# Altium schematic sheet MCU.SchDoc(MCU)
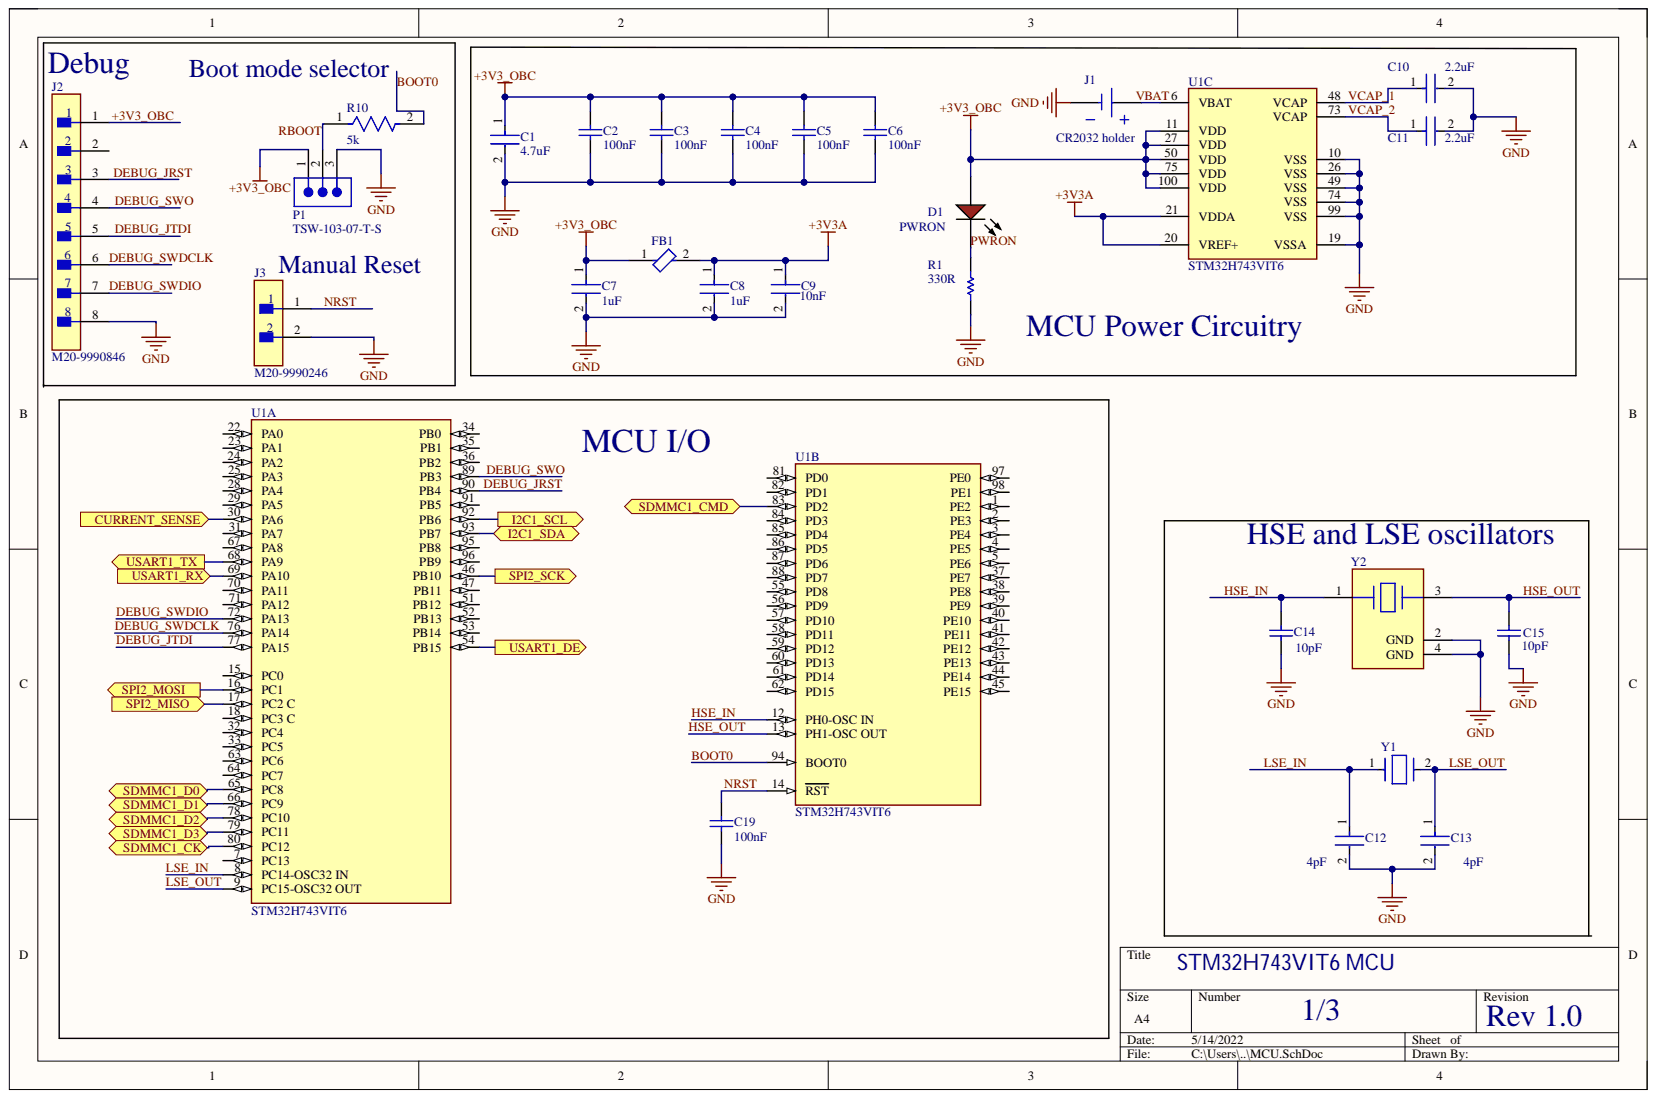
[diagram p0: MCU.SchDoc]

## Parts
C1  4.7uF  [FP-0805-L_2_0_0_1-W_1_25-IPC_B]
C2  100nF  [SAMS-C0603-2_M]
C3  100nF  [SAMS-C0603-2_M]
C4  100nF  [SAMS-C0603-2_M]
C5  100nF  [SAMS-C0603-2_M]
C6  100nF  [SAMS-C0603-2_M]
C7  1uF  [FP-CL10-IPC_C]
C8  1uF  [FP-CL10-IPC_C]
C9  10nF  [FP-CC0402-MFG]
C10  2.2uF  [FP-CC0805-0_85-MFG]
C11  2.2uF  [FP-CC0805-0_85-MFG]
C12  4pF  [FP-GRM033-0_03-IPC_B]
C13  4pF  [FP-GRM033-0_03-IPC_B]
C14  10pF  [CAPC1005X55X25ML05T05]
C15  10pF  [CAPC1005X55X25ML05T05]
C19  100nF  [SAMS-C0603-2_M]
D1  PWRON  [EVRL-0603-2_V]
FB1  MMZ1005D121CTD25  [FP-MMZ1005-IPC_C]  (value not drawn)
J1  CR2032 holder  [KEYSTONE-1025-7-2_V]
J2  M20-9990846  [FP-M20-9990846-MFG]
J3  M20-9990246  [FP-M20-9990246-MFG]
P1  TSW-103-07-T-S  [SMTC-TSW-103-07-X-S]
R1  330R  [RESC1608X55X25NL10T15]
R10  5k  [FP-MCR01-MFG]
U1  STM32H743VIT6  [FP-LQFP100-1L-MFG]  (parts A,B,C)
Y1  ABS06-107-32.768KHZ-T  [FP-ABS06-MFG]  (value not drawn)
Y2  Load Capacitance: 10pF  [FP-ABM3B-MFG]  (hidden comment: ABM3B-25.000MHZ-10-1-U-T)

## Ports
CURRENT_SENSE, I2C1_SCL, I2C1_SDA, SDMMC1_CK, SDMMC1_CMD, SDMMC1_D0, SDMMC1_D1, SDMMC1_D2, SDMMC1_D3, SPI2_MISO, SPI2_MOSI, SPI2_SCK, USART1_DE, USART1_RX, USART1_TX

## Nets
+3V3A: C8.1 C9.1 FB1.2 U1.20(VREF+) U1.21(VDDA)
GND: C1.2 C2.1 C3.1 C4.1 C5.1 C6.1 C7.2 C8.2 C9.2 C10.2 C11.2 C12.2 C13.2 C14.1 C15.1 C19.1 J1.2 J2.8 J3.2 P1.3 R1.1 U1.10(VSS) U1.19(VSSA) U1.26(VSS) U1.49(VSS) U1.74(VSS) U1.99(VSS) Y2.2(GND) Y2.4(GND)
+3V3_OBC: C1.1 C2.2 C3.2 C4.2 C5.2 C6.2 C7.1 D1.1 FB1.1 J2.1 P1.1 U1.11(VDD) U1.27(VDD) U1.50(VDD) U1.75(VDD) U1.100(VDD)
BOOT0: R10.2 U1.94(BOOT0)
CURRENT_SENSE: U1.30(PA6) ; port CURRENT_SENSE
DEBUG_JRST: J2.3 U1.90(PB4)
DEBUG_JTDI: J2.5 U1.77(PA15)
DEBUG_SWDCLK: J2.6 U1.76(PA14)
DEBUG_SWDIO: J2.7 U1.72(PA13)
DEBUG_SWO: J2.4 U1.89(PB3)
HSE_IN: C14.2 U1.12(PH0-OSC_IN) Y2.1
HSE_OUT: C15.2 U1.13(PH1-OSC_OUT) Y2.3
I2C1_SCL: U1.92(PB6) ; port I2C1_SCL
I2C1_SDA: U1.93(PB7) ; port I2C1_SDA
LSE_IN: C12.1 U1.8(PC14-OSC32_IN) Y1.1
LSE_OUT: C13.1 U1.9(PC15-OSC32_OUT) Y1.2
NRST: C19.2 J3.1 U1.14(RST)
PWRON: D1.2 R1.2
SDMMC1_CK: U1.80(PC12) ; port SDMMC1_CK
SDMMC1_CMD: U1.83(PD2) ; port SDMMC1_CMD
SDMMC1_D0: U1.65(PC8) ; port SDMMC1_D0
SDMMC1_D1: U1.66(PC9) ; port SDMMC1_D1
SDMMC1_D2: U1.78(PC10) ; port SDMMC1_D2
SDMMC1_D3: U1.79(PC11) ; port SDMMC1_D3
SPI2_MISO: U1.17(PC2_C) ; port SPI2_MISO
SPI2_MOSI: U1.16(PC1) ; port SPI2_MOSI
SPI2_SCK: U1.46(PB10) ; port SPI2_SCK
USART1_DE: U1.54(PB15) ; port USART1_DE
USART1_RX: U1.69(PA10) ; port USART1_RX
USART1_TX: U1.68(PA9) ; port USART1_TX
VCAP_1: C10.1 U1.48(VCAP)
VCAP_2: C11.1 U1.73(VCAP)
NetJ1_1: J1.1 U1.6(VBAT)
NetP1_2: P1.2 R10.1
RBOOT:
VBAT:

Not connected: J2 2; U1 1(PE2) 2(PE3) 3(PE4) 4(PE5) 5(PE6) 7(PC13) 15(PC0) 18(PC3_C) 22(PA0) 23(PA1) 24(PA2) 25(PA3) 28(PA4) 29(PA5) 31(PA7) 32(PC4) 33(PC5) 34(PB0) 35(PB1) 36(PB2) 37(PE7) 38(PE8) 39(PE9) 40(PE10) 41(PE11) 42(PE12) 43(PE13) 44(PE14) 45(PE15) 47(PB11) 51(PB12) 52(PB13) 53(PB14) 55(PD8) 56(PD9) 57(PD10) 58(PD11) 59(PD12) 60(PD13) 61(PD14) 62(PD15) 63(PC6) 64(PC7) 67(PA8) 70(PA11) 71(PA12) 81(PD0) 82(PD1) 84(PD3) 85(PD4) 86(PD5) 87(PD6) 88(PD7) 91(PB5) 95(PB8) 96(PB9) 97(PE0) 98(PE1)

## Library data of the parts
C1 = CMP-14477-004784-2: Multilayer Ceramic Capacitor 4.7 uF 16V X7R 10% SMD 0805 T/R; Capacitance: 4.7uF; Case Code (Imperial): 0805; Case Code (Metric): 2012; Case/Package: 0805; Component Kind: Standard; Construction: Flat; Contact Plating: Tin; Dielectric: X7R; Halogen Free: Halogen Free; Height: 1.25mm; Length: 2mm; Library Name: Altium Content Vault; Max Operating Temperature: 125°C; Min Operating Temperature: -55°C; Mount: Surface Mount; Number of Pins: 2; Package Quantity: 3000; Packaging: Tape and Reel; Resistor Type: Ceramic; RoHS Compliant: Yes; Series: M; Termination: SMD/SMT; Thickness: 0.053inch; Tolerance: 10%; Voltage Rating: 16V; Voltage Rating (DC): 16V; Width: 0.049inch
C2-C6 C19 = CMP-2000-05332-1: Multi-layer Ceramic Capacitor, -55 to 125 degC, 2-Pin SMD (0603), RoHS, Tape and Reel; Manufacturer: Samsung Electro-Mechanics; Capacitance: 0.1µF; Dielectric: X7R; Rated Voltage: 50V; Tolerance (%): ±10%; Case Size/Dimension: 0603; Component Kind: Standard; Datasheet URL: https://datasheet.ciiva.com/29198/456651-da-01-en-vs-kondensator-0605-29198839.pdf; Datasheet Version: Nov-10; Library Name: Altium Content Vault; Manufacturer URL: http://www.samsungsem.com/global/index.jsp
C7 C8 = CMP-13271-004127-1: Cap Ceramic 1uF 25V X5R ±10% Pad SMD 0603 +85°C T/R; Capacitance: 1uF; Case Code (Imperial): 0603; Case Code (Metric): 1608; Case/Package: 0603; Component Kind: Standard; Contact Plating: Tin; Depth: 0.8mm; Dielectric: X5R; Height: 0.8mm; Lead Free: Lead Free; Length: 1.6mm; Library Name: Altium Content Vault; Material: Ceramic; Max Operating Temperature: 85°C; Min Operating Temperature: -55°C; Mount: Surface Mount; Package Quantity: 1; Packaging: Tape and Reel; Radiation Hardening: No; Thickness: 0.035inch; Tolerance: 10%; Voltage: 25V; Voltage Rating: 25V; Voltage Rating (DC): 25V; Width: 0.031inch
C9 = CMP-03422-000804-1: CAP CER 10000PF 10V X7R 0402; Manufacturer: YAGEO; Manufacturer Part Number: CC0402KRX7R6BB103; Automotive Grade: NA; Material: Ceramic; Temperature Coefficient: 30ppm/°C; Tolerance: 0.1; Automotive: No; Capacitance: 10 nF; Category: Cap; Component Kind: Standard; ComponentLink2Description: Datasheet; ComponentLink2URL: http://www.yageo.com/documents/recent/UPY-GP_NP0_16V-to-50V_16.pdf; Device Class L1: Passive Components; Device Class L2: Capacitors; Device Class L3: Ceramic Capacitors; Digikey Description: CAP CER 10000PF 10V X7R 0402; Footprint Url: http://www.yageo.com/exep/pages/download/literatures/UPY-C_GEN_24.pdf; Height: 0.55mm; Ipc Land Pattern Name: CAPC10050X50; Lead Free: Yes; Library Name: Altium Content Vault; Mouser Description: Multilayer Ceramic Capacitors MLCC - SMD/SMT 10nF 10V X7R 10%; Octopart: https://octopart.com/cc0402krx7r6bb103-yageo-26721868; Package: CC0402; RoHS: TRUE; Temperature: -55°C to +125°C; Temperature Characteristic: X7R; Temperature Range High: +125°C; Temperature Range Low: -55°C; Value: 10 nF; Voltage Rating: 10 V
C10 C11 = CMP-1036-04838-2: CAP CER 2.2UF 25V X7R 0805; Manufacturer: Yageo; Manufacturer Part Number: CC0805KKX7R8BB225; Material: Ceramic; Tolerance: 0.1; Automotive: No; Capacitance: 2.2 uF; Category: Cap; Component Kind: Standard; ComponentLink2Description: Datasheet; ComponentLink2URL: http://www.yageo.com/documents/recent/UPY-GPHC_Y5V_6.3V-to-50V_8.pdf; Device Class L1: Passive Components; Device Class L2: Capacitors; Device Class L3: Ceramic Capacitors; Digikey Description: CAP CER 2.2UF 25V X7R 0805; Footprint Url: http://www.yageo.com/exep/pages/download/literatures/UPY-C_GEN_24.pdf; Height: 0.95mm; Ipc Land Pattern Name: CAPC200125X85; Lead Free: Yes; Library Name: Altium Content Vault; Mouser Description: Multilayer Ceramic Capacitors MLCC - SMD/SMT 2.2uF 25V X7R 10%; Octopart: https://octopart.com/cc0805kkx7r8bb225-yageo-42522865; Package: CC0805-0.85; RoHS: TRUE; Temperature: -30°C to +85°C; Temperature Characteristic: X7R; Temperature Range High: +85°C; Temperature Range Low: -30°C; Value: 2.2 uF; Voltage Rating: 25 V
C12 C13 = CMP-2010-01389-2: Chip Multilayer Ceramic Capacitors for General Purpose, 0201, 4.0pF, C0G, 30ppm/°C, 0.25pF, 25V; Capacitance: 4pF; Case Code (Imperial): 0201; Case Code (Metric): 0603; Case/Package: 0201; Component Kind: Standard; Dielectric: C0G; Height: 0.3mm; Lead Free: Lead Free; Length: 0.024inch; Library Name: Altium Content Vault; Max Operating Temperature: 125°C; Min Operating Temperature: -55°C; Mount: Surface Mount; Package Quantity: 15000; Packaging: Tape and Reel; Termination: SMD/SMT; Thickness: 0.013inch; Voltage Rating: 25V; Voltage Rating (DC): 25V; Width: 0.012inch
C14 C15 = CMP-2100-03589-1: Chip Capacitor, 10 pF, +/- 5%, 50 V, -55 to 125 degC, 0402 (1005 Metric), RoHS, Tape and Reel; Manufacturer: Yageo; Dielectric: C0G; Dielectric Material: Ceramic; Insulation Resistance: 100GR; Temperature Coefficient: 30ppm/degC; Voltage: 50V; Capacitance: 10pF; Case Code (Imperial): 0402; Case Code (Metric): 1005; Case/Package: 0402; Component Kind: Standard; Composition: Ceramic; Datasheet URL: http://www.yageo.com/documents/recent/UPY-GP_NP0_16V-to-50V_14.pdf; Datasheet Version: Rev. 14, 07/2016; Depth: 0.5mm; Height: 0.5mm; Lead Free: LeadFree; Length: 1mm; Library Name: Altium Content Vault; Manufacturer URL: http://www.yageo.com/; Max Operating Temperature: 125degC; Min Operating Temperature: -55degC; Mount: SurfaceMount; Number of Pins: 2; Package Description: 2-Pin Surface Mount Device, Body 1 x 0.5 mm; Package Quantity: 1; Package Reference: 0402; Packaging: TapeandReel; Radiation Hardening: No; REACH SVHC: NoSVHC; RoHSCompliant: True; Termination: SMD/SMT; Thickness: 0.022inch; Tolerance: 5%; Voltage Rating: 50V; Voltage Rating (DC): 50V; Width: 0.02inch
D1 = CMP-2100-03578-1: Chip LED, Red, 5 V, 25 mA, -40 to 85 degC, 2-Pin SMD (0603), RoHS, Tape and Reel; Manufacturer: Everlight Electronics; Forward Voltage: 2.2V; Lens Style: Clear; Case/Package: 0603; Colour: Red; Component Kind: Standard; Datasheet URL: https://datasheet.ciiva.com/4688/el-19-217-r6c-al1m2vy-3tpdf-187026-4688713.pdf; Datasheet Version: Rev. 1, 01/2005; Lead Free: LeadFree; Library Name: Altium Content Vault; Manufacturer URL: http://www.everlight.com/; Package Description: 2-Pin Surface Mount Device, Body 1.6 x 0.8 mm; Package Reference: 0603; RoHSCompliant: false
FB1 = CMP-08257-000320-1: Signal Line Ferrite Bead 120Ω @ 100MHz 350mA DCR 600mΩ AEC-Q200 SMD 0402; DC Resistance (DCR): 600mR; Impedance: 120R; Number of Lines: 1; Case Code (Imperial): 0402; Case Code (Metric): 1005; Case/Package: 0402; Component Kind: Standard; Current Rating: 350mA; DC Resistance-Max: 600mR; Height: 0.022inch; Lead Free: Lead Free; Length: 0.039inch; Library Name: Altium Content Vault; Max Current Rating: 350mA; Max Operating Temperature: 125°C; Min Operating Temperature: -55°C; Mount: Surface Mount; Package Quantity: 10000; Packaging: Tape and Reel; Ratings: AEC-Q200; RoHS Compliant: Yes; Series: MMZ; Width: 0.02inch
J1 = CMP-0465-00001-2: Holder for 2477 or 2430 Cells P/N 1025-7, Body 27.762 x 30.963 mm, THM; Manufacturer: Keystone; # of Cells: 1; Cell Size: 24 mm; Component Kind: Standard; ComponentLink1Description: Manufacturer URL; ComponentLink1URL: http://www.keyelco.com/; ComponentLink2Description: Datasheet; ComponentLink2URL: http://www.keyelco.com/product-pdf.cfm?p=3950; DatasheetVersion: Rev.C; Library Name: Altium Content Vault; Material: Plastic; Mounting Technology: Through Hole; PackageDescription: 2-Pin Hand Drawn, Body 27.762 x 30.963 mm; PackageReference: KEYSTONE-1025-7-2; PartNumber: 1025-7; Switch Current Limit (Typ) (A): 1; Type: HOLDER
J2 = CMP-15831-000033-1: CONN HEADER VERT 8POS 2.54MM; Connector Type: Male Pin; Contact Resistance: 30mR; Current Rating: 3A; Gender: Male; Insulation Resistance: 1GR; Number of Contacts: 8; Case/Package: SIL; Color: Black; Component Kind: Standard; Contact Material: Brass; Contact Mating Length: 0.24inch; Contact Plating: Tin; Contact Type: Male Pin; Depth: 2.54mm; Housing Color: Black; Housing Material: Nylon; Length: 20.32mm; Library Name: Altium Content Vault; Max Current Rating: 3A; Max Operating Temperature: 105°C; Min Operating Temperature: -40°C; Mount: Through Hole; Number of Positions: 8; Number of Rows: 1; Orientation: Straight; Package Quantity: 1000; Packaging: Bulk; Pitch: 2.54mm; Radiation Hardening: No; REACH SVHC: No SVHC; RoHS Compliant: Yes; Series: M20; Termination: Solder
J3 = CMP-15831-000009-1: Connector Header Through Hole 2 position 0.100" (2.54mm); Manufacturer: Harwin; Conn Gender: Male; Conn Orientation: Vertical; Current Rating: 3A; Number Of Contacts: 2; Automotive: No; Category: Conn; Component Kind: Standard; ComponentLink2Description: Datasheet; ComponentLink2URL: https://cdn.harwin.com/pdfs/M20-999.pdf; Contact Material: Tin,Nickel; Device Class L1: Connectors; Device Class L2: Headers and Wire Housings; Device Class L3: unset; Digikey Description: CONN HEADER VERT 2POS 2.54MM; Height: 8.99mm; Lead Free: Yes; Library Name: Altium Content Vault; Manufacturer Part Number: M20-9990246; Mouser Description: 2.54mm (0.1") Pitch SIL Vertical PC Tail Pin Header, 6.1mm mating pin height, tin, 2 contacts; Number Of Rows: 1; Octopart: https://octopart.com/m20-9990246-harwin-2590635; Package: M20-9990246; Pitch: 2.54mm; RoHS: TRUE; Temperature: -40°C to +105°C; Temperature Range High: +105°C; Temperature Range Low: -40°C
P1 = CMP-1024-00332-1: 0.025" SQ Post Header, Through-hole, Vertical, -55 to 105 degC, 2.54 mm Pitch, 3-Pin, Male, RoHS; Manufacturer: Samtec; Pitch: 2.54 mm; Component Kind: Standard; ComponentLink1Description: Manufacturer URL; ComponentLink1URL: http://www.samtec.com; ComponentLink2Description: Datasheet; ComponentLink2URL: http://www.samtec.com/documents/webfiles/pdf/TSW_TH.PDF; ComponentLink3Description: Package Specification; ComponentLink3URL: http://www.samtec.com/documents/webfiles/cpdf/TSW-XXX-XX-XXX-X-XX-XXX-MKT.pdf; DatasheetVersion: F-214; Library Name: Altium Content Vault; Mounting Technology: Through-hole; Orientation: Vertical; PackageDescription: .025[0.64] SQ. Post Terminal Strip Assembly; PackageReference: TSW-103-07-X-S; PackageVersion: DM, 8/1988; PartNumber: TSW-103-07-T-S; RoHS: True; Temperature Range: -55 to 105 degC
R1 = CMP-1659-00020-2: Chip Resistor, 330 Ohm, +/- 1%, 0.1 W, -55 to 155 degC, 0603 (1608 Metric), RoHS, Tape and Reel; Capacitance: 47 uF; ESR: 800 mohm; Tolerance: 10%; Voltage Rating: 16 V; Case Code (Imperial): 2917; Case Code (Metric): 7343; Case/Package: 2917; Component Kind: Standard; ComponentLink1Description: Manufacturer URL; ComponentLink1URL: http://www.yageo.com/; ComponentLink2Description: Datasheet; ComponentLink2URL: http://www.yageo.com/documents/recent/PYu-RC_Group_51_RoHS_L_04.pdf; DatasheetVersion: Rev. 4, 02/2016; Height: 3.1 mm; Length: 7.3 mm; Library Name: Altium Content Vault; Max Operating Temperature: 125degC; Min Operating Temperature: -55degC; Mount: Surface Mount; Package Quantity: 500; PackageDescription: 2-Pin Surface Mount Device, Body 1.6 x 0.8 mm; PackageReference: 0603; Packaging: Tape and Reel; Pins: 2; Radiation Hardening: No; REACH SVHC: No SVHC; RoHSCompliant: True; Voltage Rating (DC): 16 V; Width: 4.3 mm
R10 = CMP-08839-006175-1: Thick Film Chip Resistor, 0402, 5.1kΩ, 1%, 100ppm/°C, 0.063W, 50V; Max Power Dissipation: 63mW; Resistance: 5.1kR; Temperature Coefficient: 400ppm/°C; Case Code (Imperial): 0402; Case Code (Metric): 1005; Case/Package: 0402; Component Kind: Standard; Construction: Rectangular; Depth: 0.5mm; Features: Automotive AEC-Q200; Height: 0.016inch; Lead Free: Lead Free; Length: 0.039inch; Library Name: Altium Content Vault; Max Operating Temperature: 155°C; Military Standard: Not; Min Operating Temperature: -55°C; Number of Terminations: 2; Package Quantity: 10000; Packaging: Tape and Reel; Resistor Type: Thick Film; RoHS Compliant: Yes; Series: MCR01; Tolerance: 1%; Voltage Rating (DC): 50V; Width: 0.02inch
U1 = CMP-12105-000050-1: IC MCU 32BIT 2MB FLASH 100LQFP; Manufacturer: STMicroelectronics; Core Architecture: Arm Cortex-M7; Data Bus Width: 32bits; Frequency: 480MHz; Interface: I2C,SPI,UART,USART,USB,LIN,IrDA,Ethernet,I2S,Other; Max Junction Temp: +125°C; Max Supply Voltage: 3.6V; Memory Size: 2MBytes; Min Supply Voltage: 1.71V; Number Of A/D Converters: 3; Number Of Adc Channels: 36; Number Of Cores: 1; Number Of I2C Channels: 4; Number Of I/Os: 82; Number Of Pwm Channels: 42; Number Of Spi Channels: 6; Number Of Timers/Counters: 20; Number Of Uart Channels: 9; Number Of Usb Channels: 2; Ram Size: 1060KBytes; Standoff Height: 0.05mm; Ambient Temperature Range High: +85°C; Ambient Temperature Range Low: -40°C; Automotive: No; Category: IC; Component Kind: Standard; ComponentLink2Description: Datasheet; ComponentLink2URL: https://www.st.com/resource/en/datasheet/stm32h743vi.pdf; Device Class L1: Integrated Circuits (ICs); Device Class L2: Embedded Processors and Controllers; Device Class L3: Microcontrollers; Digikey Description: IC MCU 32BIT 2MB FLASH 100LQFP; Height: 1.6mm; Ipc Land Pattern Name: QFP50P1600X1600X160-100; Lead Free: Yes; Library Name: Altium Content Vault; Manufacturer Part Number: STM32H743VIT6; Memory Type: FLASH; Number Of Ethernet Channels: 1; Octopart: https://octopart.com/stm32h743vit6-stmicroelectronics-76372527; Package: LQFP100-1L; RoHS: TRUE; Temperature: -40°C to +125°C; Temperature Range High: +125°C; Temperature Range Low: -40°C
Y1 = CMP-2000-05734-2: CRYSTAL 32.7680KHZ 4PF SMD; ESR (Equivalent Series Resistance): 60kR; Frequency Stability: 175ppm; Frequency Tolerance: 20ppm; Series Resistance: 80kR; Case Code (Imperial): 0805; Case Code (Metric): 2012; Case/Package: 0805; Component Kind: Standard; Frequency: 32.768kHz; Height: 0.024inch; Lead Free: Lead Free; Length: 0.079inch; Library Name: Altium Content Vault; Load Capacitance: 4pF; Max Operating Temperature: 85°C; Min Operating Temperature: -40°C; Mount: Surface Mount; Number of Pins: 2; Package Quantity: 3000; Packaging: Tape and Reel; Radiation Hardening: No; REACH SVHC: No SVHC; RoHS Compliant: Yes; Termination: SMD/SMT; Tolerance: 20ppm; Width: 0.047inch
Y2 = CMP-27762-002145-1: Crystal 25MHz ±10ppm 10pF SMD-4 5mm x 3.2mm; ESR (Equivalent Series Resistance): 50R; Case/Package: CSMD; Component Kind: Standard; Depth: 3.2mm; Frequency: 25MHz; Frequency Stability: 10ppm; Frequency Tolerance: 10ppm; Height: 0.043inch; Lead Free: Lead Free; Length: 5mm; Library Name: Altium Content Vault; Max Operating Temperature: 60°C; Min Operating Temperature: -10°C; Mount: Surface Mount; Number of Pins: 4; Operating Frequency: 25 MHz; Package Quantity: 1000; Packaging: Tape and Reel; Radiation Hardening: No; REACH SVHC: No SVHC; Resistor Type: Ceramic; RoHS Compliant: Yes; Series: ABM3B; Termination: SMD/SMT; Tolerance: 10ppm; Width: 3.2mm
